# Altium schematic sheet Main.SchDoc(Main)
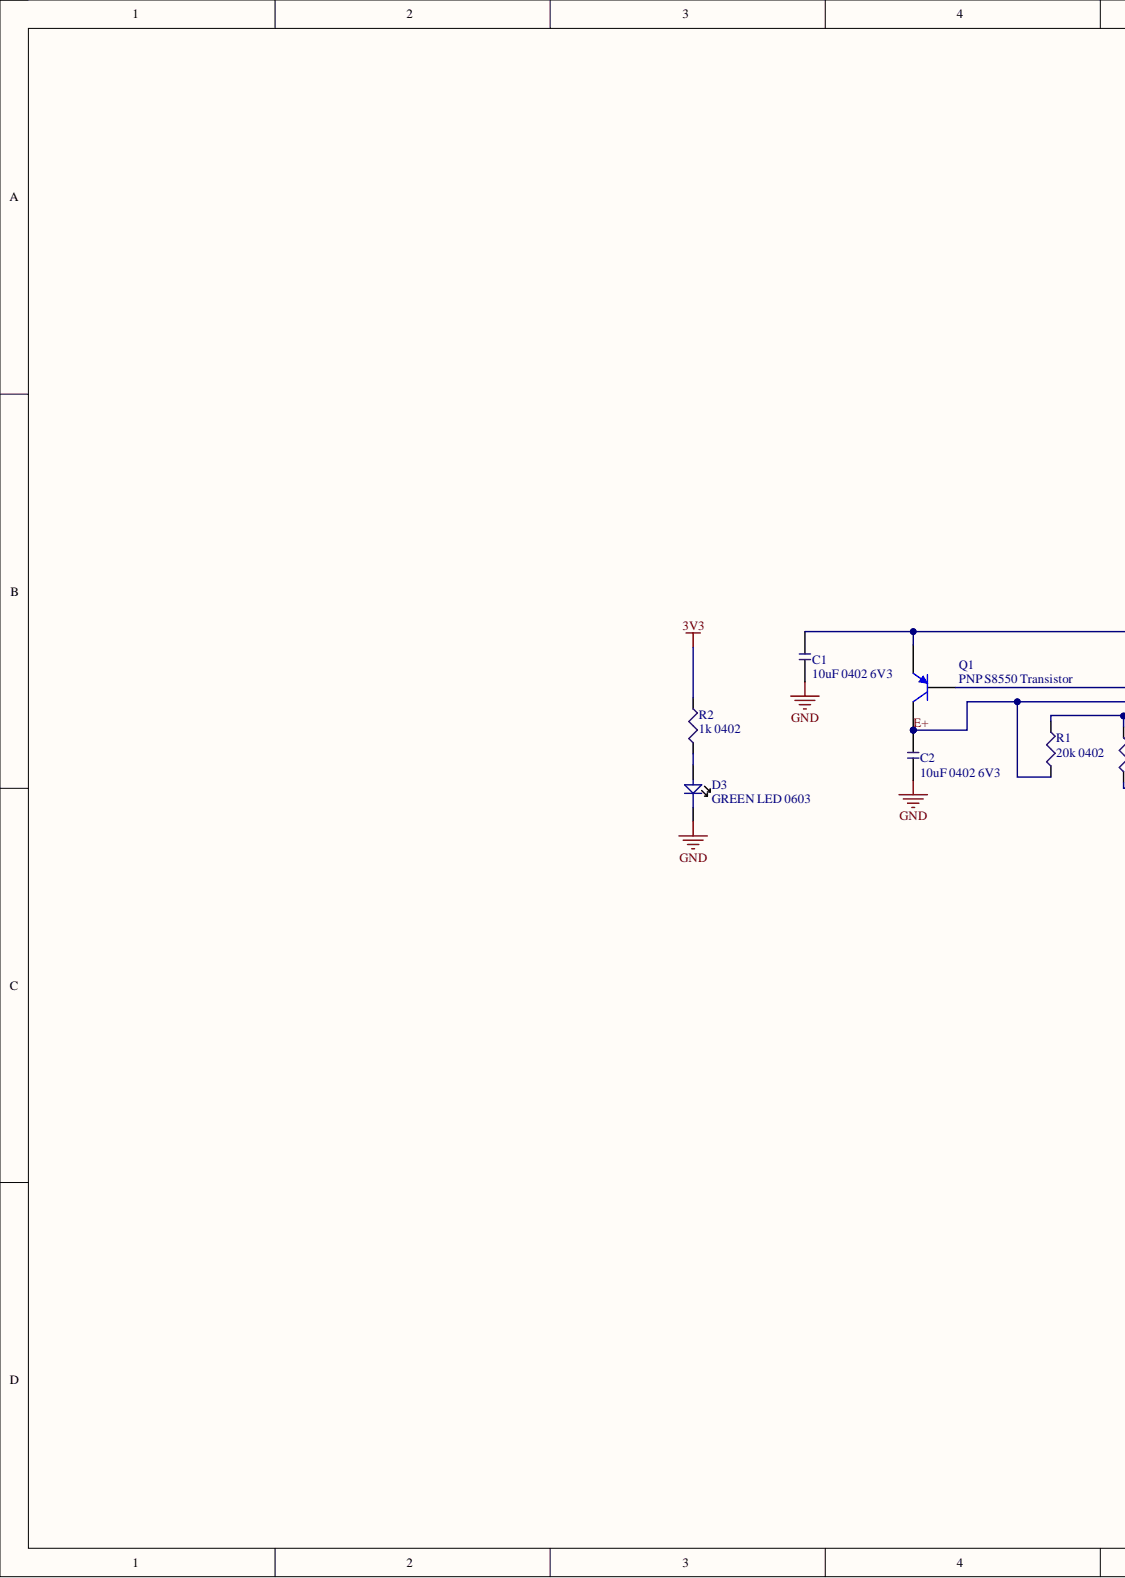
[diagram p0: Main.SchDoc, left]
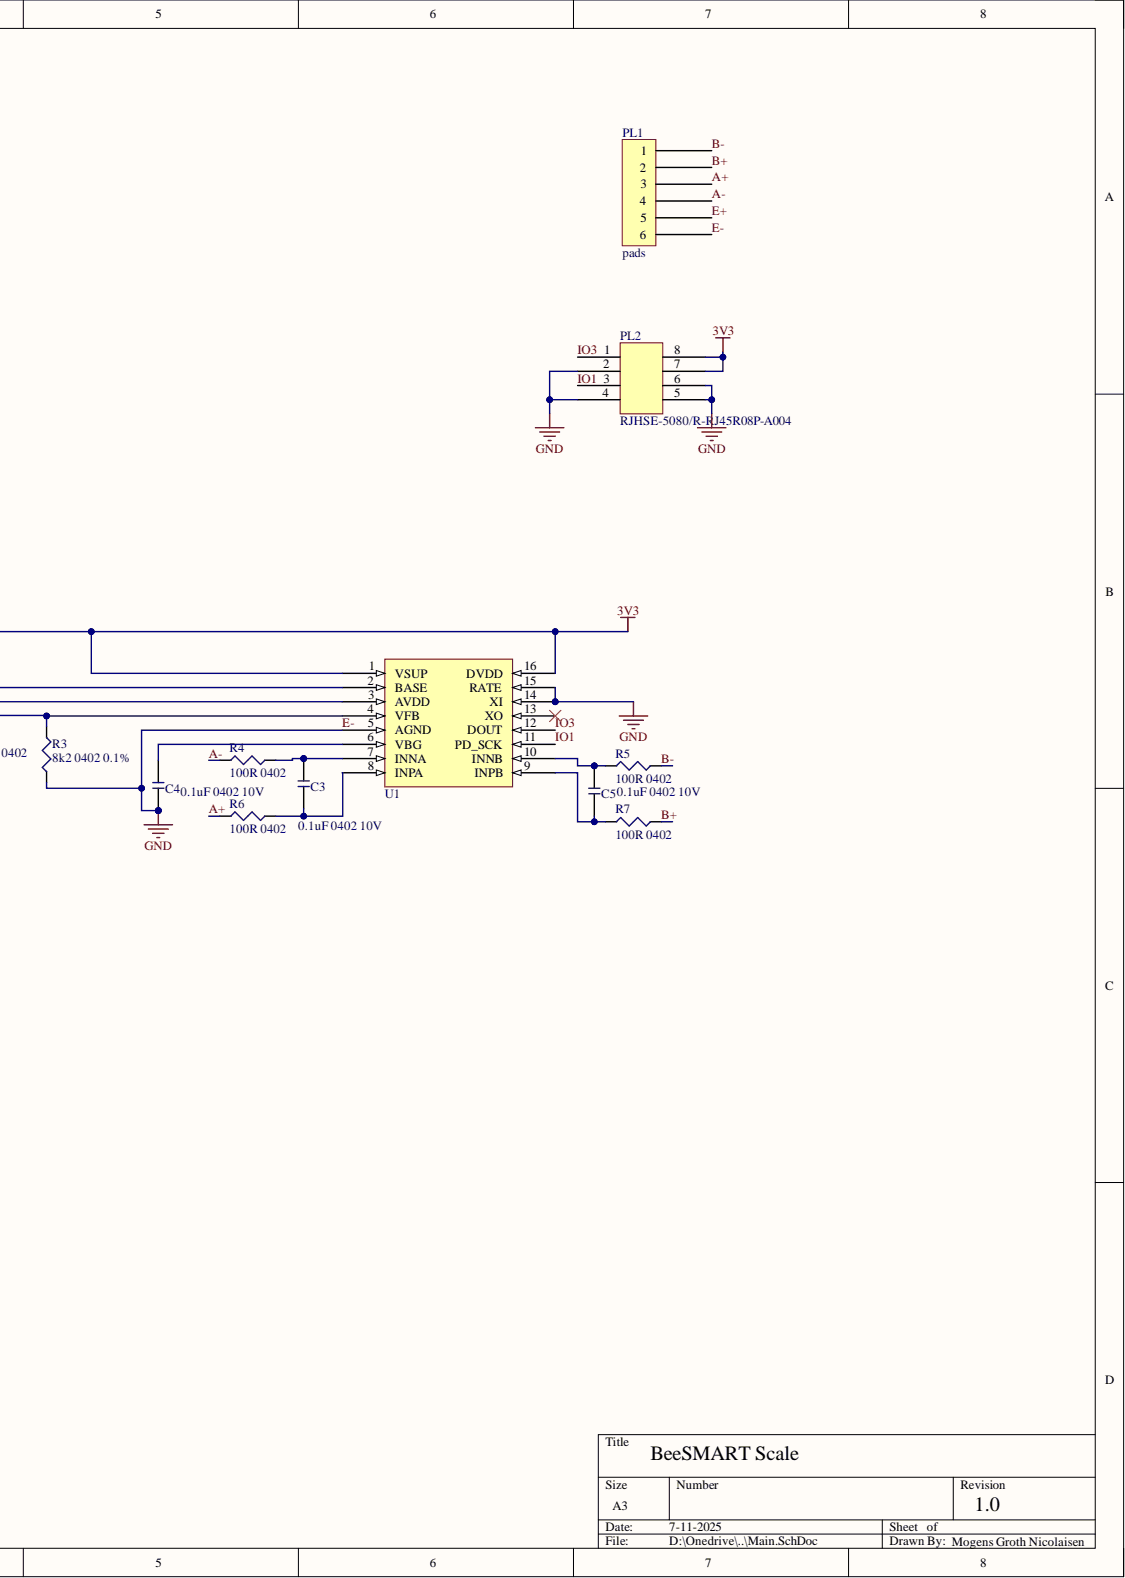
[diagram p1: Main.SchDoc, right]

## Parts
C1  10uF 0402 6V3  value 10uF  [CAP_0402]
C2  10uF 0402 6V3  value 10uF  [CAP_0402]
C3  0.1uF 0402 10V  [CAP_0402]
C4  0.1uF 0402 10V  [CAP_0402]
C5  0.1uF 0402 10V  [CAP_0402]
D3  GREEN LED 0603  [0603 LED]
PL1  pads  [6-pin 2.54mm header - female right angle]
PL2  RJHSE-5080/R-RJ45R08P-A004  [AMPHENOL RJHSE-5080]
Q1  PNP S8550 Transistor  [SOT95P245X110-3N]
R1  20k 0402  Case/Package: 0402  [RES_0402]
R2  1k 0402  value 1k  Case/Package: 0402  [RES_0402]
R3  8k2 0402 0.1%  value 8k2  Case/Package: 0402  [RES_0402]
R4  100R 0402  value 100R  Case/Package: 0402  [RES_0402]
R5  100R 0402  value 100R  Case/Package: 0402  [RES_0402]
R6  100R 0402  value 100R  Case/Package: 0402  [RES_0402]
R7  100R 0402  value 100R  Case/Package: 0402  [RES_0402]
U1  HX711  [TSOP127P600X160-16N]  (value not drawn)

## Nets
3V3: C1.2 PL2.7 PL2.8 Q1.1 R2.2 U1.1(VSUP) U1.16(DVDD)
A+: PL1.3 R6.2
A-: PL1.4 R4.2
B+: PL1.2 R7.1
B-: PL1.1 R5.1
E+: C2.2 PL1.5 Q1.3 R1.1 U1.3(AVDD)
E-: C1.1 C2.1 C4.2 D3.2 PL1.6 PL2.2 PL2.4 PL2.5 PL2.6 R3.1 U1.5(AGND) U1.14(XI) U1.15(RATE)
IO1: PL2.3 U1.11(PD_SCK)
IO3: PL2.1 U1.12(DOUT)
NetC3_1: C3.1 R4.1 U1.7(INNA)
NetC3_2: C3.2 R6.1 U1.8(INPA)
NetC4_1: C4.1 U1.6(VBG)
NetC5_1: C5.1 R5.2 U1.10(INNB)
NetC5_2: C5.2 R7.2 U1.9(INPB)
NetD3_1: D3.1 R2.1
NetQ1_2: Q1.2 U1.2(BASE)
NetR1_2: R1.2 R3.2 U1.4(VFB)

Not connected: U1 13(XO)

## Library data of the parts
C1 C2 = CMP-00011-3: 10uF 20% 0402 6V3 X6S; Component Kind: Standard; Current Rating: -; Library Name: ON Development Vault; Tolerance: 20%; Voltage: 6V3
C3-C5 = CMP-00101-1: 0.1uF 0402 10V X7R 10%; Value: 100 nF; Component Kind: Standard; Library Name: ON Development Vault; Tolerance: 10 %; Voltage: 10 V
D3 = CMP-008-0000-1: SMD GREEN WATER CLEAR; Mfg. Part Number: APT1608SGC; Component Kind: Standard; Library Name: ON Development Vault
PL1 = CMP-003-0023-0.1: Pin Header 6-pin 2.54mm female right angle; Component Kind: Standard; Library Name: ON Development Vault
PL2 = CMP-003-0022-0.1: CONN MOD JACK 8P8C R/A UNSHLD; Connector Type: Jack, RJ45; Contact Resistance: 20mR; Current Rating: 1.5A; Direction: Up; Gender: Female; Max Voltage Rating (AC): 125V; Number of Contacts: 8; Number of Ports: 1; Pitch: 2.03mm; Shielding: Unshielded; Colour: Black; Component Kind: Standard; Contact Material: Bronze; Contact Plating: Gold; Depth: 14.99mm; Flammability Rating: UL94 V-0; Housing Material: Thermoplastic; Lead Free: Lead Free; Length: 15.75mm; Library Name: ON Development Vault; Max Current Rating: 1.5A; Max Operating Temperature: 85°C; Min Operating Temperature: -55°C; Mount: Panel, Through Hole; Number of Positions: 8; Number of Positions Loaded: 8; Number of Rows: 1; Orientation: Right Angle; Package Quantity: 36; Packaging: Tray; Radiation Hardening: No; REACH SVHC: Unknown; Termination: Solder; Voltage Rating: 125V; Voltage Rating (DC): 125V
Q1 = CMP-014-0022-0.0: S8550; Part no.: C105432; Component Kind: Standard; Library Name: ON Development Vault
R1 = CMP-001-0026-3: RES SMD 22K OHM 1% 1/16W 0402; Mfg. Part Number: RMCF0402FT22K0; Component Kind: Standard; Library Name: ON Development Vault; Power: 1/16W; Tolerance: 1%; Value: 22k
R2 = CMP-001-0025-3: RES SMD 1K OHM 1% 1/16W 0402; Mfg. Part Number: CRG0402F1K0; Component Kind: Standard; Library Name: ON Development Vault; Power: 1/16W; Tolerance: 1%
R3 = CMP-001-0038-2: TE CONNECTIVITY / NEOHM         CPF0402B8K2E1             SMD Chip Resistor, Thin Film, 8.2 kohm, 25 V, 0402 [1005 Metric], 63 mW,  0.1%, CPF Series; Power: 1/16 W; Component Kind: Standard; Library Name: ON Development Vault; Tolerance: 0.1 %
R4-R7 = CMP-001-0001-5: 100R 1% 0402 63mW; Component Kind: Standard; Library Name: ON Development Vault; Power: 63mW; Tolerance: 1%
U1 = CMP-00183-2: 24-Bit Analog-to-Digital Converter (ADC) for Weigh Scales; Part no: C6705483; Component Kind: Standard; Library Name: ON Development Vault
